# Altium schematic sheet [03] - 28PINS SCHEMATIC.SchDoc("[03] - 28PINS SCHEMATIC") (continued)

## Library data of the parts
C1 C2 = EEE-1AA101AP: Cap Aluminum 100uF 10V 20% (6.3 X 5.4mm) SMD 70mA 2000h 85°C T/R; Manufacturer: Panasonic; Dissipation Factor: 0.26%; Leakage Current: 3uA; Life (Hours): 2000Hour; Capacitance: 100uF; Case/Package: Radial; Category: Aluminum Electrolytic Capacitors; Component Kind: Standard; ComponentLink1Description: https://datasheet.ciiva.com/2985/aba0000ce115-2985038.pdf?src-supplier=Avnet; ComponentLink1URL: https://datasheet.ciiva.com/2985/aba0000ce115-2985038.pdf?src-supplier=Avnet; ComponentLink2Description: https://datasheet.ciiva.com/14604/70255709-14604540.pdf?src-supplier=Allied+Electronics; ComponentLink2URL: https://datasheet.ciiva.com/14604/70255709-14604540.pdf?src-supplier=Allied+Electronics; ComponentLink3Description: https://datasheet.ciiva.com/2985/aba0000ce115-2985039.pdf?src-supplier=Avnet; ComponentLink3URL: https://datasheet.ciiva.com/2985/aba0000ce115-2985039.pdf?src-supplier=Avnet; Construction: Cylindrical; Diameter: 6.3mm; Features: General Purpose; Height: 7.8mm; Height - Seated (Max): 0.213inch; Length: 5.5mm; Library Name: 28Pins_Project_V1I1.SchLib; Manufacturer Part Number: EEE-1AA101AP; Max Operating Temperature: 85°C; Min Operating Temperature: -40°C; Mount: Surface Mount,PCB; Package Quantity: 1000; Packaging: Tape and Reel; Pricing 1: 1=0.52000, 10=0.36000, 50=0.25200, 100=0.21600, 500=0.16200 (USD); Radiation Hardening: No; Ripple Current: 70mA; RoHS: Yes; RoHS Compliant: Yes; Series: VS; Stock 1: 1960; Supplier 1: Digi-Key; Supplier Part Number 1: PCE4565CT-ND; Termination: SMD/SMT; Tolerance: 20%; Voltage Rating: 10V; Voltage Rating (DC): 10V
C3 C12 C13 C19 C21 C22 C34 = C2012X5R1A106K085AB: C Series 0805 10 uF 10 V ±10 % Tolerance X5R SMT Multilayer Ceramic Capacitor; Manufacturer: TDK; Capacitance: 10uF; Tolerance: 10%; Voltage Rating: 10V; Case Code (Imperial): 0805; Case Code (Metric): 2012; Case/Package: 0805; Category: Ceramic Capacitors; Component Kind: Standard; ComponentLink1Description: https://datasheet.ciiva.com/26834/getdatasheetpartid-731846-26834407.pdf?src-supplier=Verical; ComponentLink1URL: https://datasheet.ciiva.com/26834/getdatasheetpartid-731846-26834407.pdf?src-supplier=Verical; ComponentLink2Description: https://datasheet.ciiva.com/7615/tdkmlcccaprange-7615065.pdf?src-supplier=Digi-Key; ComponentLink2URL: https://datasheet.ciiva.com/7615/tdkmlcccaprange-7615065.pdf?src-supplier=Digi-Key; ComponentLink3Description: https://datasheet.ciiva.com/7615/tdkmlcccaprange-7615066.pdf?src-supplier=Digi-Key; ComponentLink3URL: https://datasheet.ciiva.com/7615/tdkmlcccaprange-7615066.pdf?src-supplier=Digi-Key; ComponentLink4Description: https://datasheet.ciiva.com/7615/tdkmlcccaprange-7615067.pdf?src-supplier=Digi-Key; ComponentLink4URL: https://datasheet.ciiva.com/7615/tdkmlcccaprange-7615067.pdf?src-supplier=Digi-Key; Construction: Flat; Depth: 1.25mm; Dielectric: X5R; Dielectric Material: Ceramic; Height: 0.85mm; Lead Free: Lead Free; Length: 2mm; Library Name: 28Pins_Project_V1I1.SchLib; Manufacturer Part Number: C2012X5R1A106K085AB; Max Operating Temperature: 85°C; Min Operating Temperature: -55°C; Mount: Surface Mount; Package Quantity: 1; Packaging: Tape and Reel; Pricing 1: 1=0.26000, 10=0.17700, 50=0.11800, 100=0.10030, 500=0.07078, 1000=0.06193 (USD); Radiation Hardening: No; RoHS: Yes; RoHS Compliant: Yes; Series: C; Stock 1: 426139; Supplier 1: Digi-Key; Supplier Part Number 1: 445-7658-1-ND; Thickness: 0.037inch; Voltage Rating (DC): 10V; Width: 0.049inch
C4-C6 C8 C11 C14-C17 C20 C23 C24 C26 C28-C33 = C2012X7R1H104K085AA: C Series 0805 0.1 uF 50 V ±10 % Tolerance X7R SMT Multilayer Ceramic Capacitor; Manufacturer: TDK; Capacitance: 100nF; Tolerance: 10%; Voltage: 50V; Case Code (Imperial): 0805; Case Code (Metric): 2012; Case/Package: 0805; Category: Ceramic Capacitors; Component Kind: Standard; ComponentLink1Description: https://datasheet.ciiva.com/11788/0900766b80c1bb00-11788128.pdf?src-supplier=Rs; ComponentLink1URL: https://datasheet.ciiva.com/11788/0900766b80c1bb00-11788128.pdf?src-supplier=Rs; ComponentLink2Description: https://datasheet.ciiva.com/6250/tdkmlcccaprange-6250821.pdf?src-supplier=Digi-Key; ComponentLink2URL: https://datasheet.ciiva.com/6250/tdkmlcccaprange-6250821.pdf?src-supplier=Digi-Key; ComponentLink3Description: https://datasheet.ciiva.com/6250/tdkmlcccaprange-6250824.pdf?src-supplier=Digi-Key; ComponentLink3URL: https://datasheet.ciiva.com/6250/tdkmlcccaprange-6250824.pdf?src-supplier=Digi-Key; ComponentLink4Description: https://datasheet.ciiva.com/24191/e412-c-24191447.pdf?src-supplier=Future+Electronics; ComponentLink4URL: https://datasheet.ciiva.com/24191/e412-c-24191447.pdf?src-supplier=Future+Electronics; ComponentLink5Description: https://datasheet.ciiva.com/23803/1796654-23803035.pdf?src-supplier=Newark; ComponentLink5URL: https://datasheet.ciiva.com/23803/1796654-23803035.pdf?src-supplier=Newark; ComponentLink6Description: https://datasheet.ciiva.com/28602/1571323-28602204.pdf?src-supplier=Element14; ComponentLink6URL: https://datasheet.ciiva.com/28602/1571323-28602204.pdf?src-supplier=Element14; Construction: Flat; Depth: 1.25mm; Dielectric: X7R; Dielectric Material: Ceramic; Height: 0.85mm; Lead Free: Lead Free; Length: 2mm; Library Name: 28Pins_Project_V1I1.SchLib; Manufacturer Part Number: C2012X7R1H104K085AA; Max Operating Temperature: 125°C; Min Operating Temperature: -55°C; Mount: Surface Mount; Number of Pins: 2; Package Quantity: 1; Packaging: Tape and Reel; Pricing 1: 1=0.13000, 10=0.09500, 50=0.05400, 100=0.04480, 500=0.03142, 1000=0.02601 (USD); Radiation Hardening: No; Reach SVHC Compliant: Yes; Resistor Type: Ceramic; RoHS: Yes; RoHS Compliant: Yes; Series: C; Stock 1: 1513361; Supplier 1: Digi-Key; Supplier Part Number 1: 445-7534-1-ND; Termination: SMD/SMT; Thickness: 0.037inch; Voltage Rating: 50V; Voltage Rating (DC): 50V; Weight: 0.000194oz; Width: 0.049inch
C7 = C2012X5R1E105K125AA: CAP CER 1UF 25V X5R 0805; Manufacturer: TDK; Dielectric Material: Ceramic; Tolerance: 10%; Case Code (Imperial): 0805; Case Code (Metric): 2012; Case/Package: 0805; Category: Ceramic Capacitors; Component Kind: Standard; ComponentLink1Description: https://datasheet.ciiva.com/28602/1744655-28602171.pdf?src-supplier=Element14; ComponentLink1URL: https://datasheet.ciiva.com/28602/1744655-28602171.pdf?src-supplier=Element14; ComponentLink2Description: https://datasheet.ciiva.com/15192/getdatasheetpartid-726707-15192140.pdf?src-supplier=Verical; ComponentLink2URL: https://datasheet.ciiva.com/15192/getdatasheetpartid-726707-15192140.pdf?src-supplier=Verical; ComponentLink3Description: https://datasheet.ciiva.com/16449/e412-c-16449595.pdf?src-supplier=Future+Electronics; ComponentLink3URL: https://datasheet.ciiva.com/16449/e412-c-16449595.pdf?src-supplier=Future+Electronics; Construction: Flat; Depth: 1.25mm; Dielectric: X5R; Height: 1.25mm; Lead Free: Lead Free; Length: 2mm; Library Name: 28Pins_Project_V1I1.SchLib; Manufacturer Part Number: C2012X5R1E105K125AA; Max Operating Temperature: 85°C; Min Operating Temperature: -55°C; Mount: Surface Mount; Number of Pins: 2; Package Quantity: 2000; Packaging: Tape and Reel; Pricing 1: 1=0.21000, 10=0.14500, 50=0.08720, 100=0.07370, 500=0.05042, 1000=0.04460 (USD); Radiation Hardening: No; Reach SVHC Compliant: Yes; Resistor Type: Ceramic; RoHS: Yes; RoHS Compliant: Yes; Series: C; Stock 1: 32867; Supplier 1: Digi-Key; Supplier Part Number 1: 445-1419-1-ND; Termination: SMD/SMT; Thickness: 0.057inch; Voltage Rating: 25V; Voltage Rating (DC): 25V; Weight: 0.000194oz; Width: 0.049inch
C9 C10 C25 C27 = C0805C180J5GACAUTO: .050 X .050 C.L. FEMALE IDC ASSE; Manufacturer: KEMET; Category: Ceramic Capacitors; Component Kind: Standard; Library Name: 28Pins_Project_V1I1.SchLib; Manufacturer Part Number: C0805C180J5GACAUTO; Pricing 1: 1=0.18000, 10=0.12600, 50=0.07160, 100=0.05930, 500=0.04158, 1000=0.03443 (USD); RoHS: Yes; RoHS Compliant: Yes; Stock 1: 1163; Supplier 1: Digi-Key; Supplier Part Number 1: 399-C0805C180J5GACAUTOCT-ND
D1 = LG L29K-F2J1-24-Z: GREEN LED; Component Kind: Standard; Library Name: 28Pins_Project_V1I1.SchLib
D2-D4 = LO L29K-H2K1-24-Z: ORANGE LED MISSING LINK TO DIGIKEY; Component Kind: Standard; Library Name: 28Pins_Project_V1I1.SchLib
D5 D6 = BAT54HT1G: VR=30V IF=200mA 10pF P=200mW; Manufacturer: ON Semiconductor / Fairchild; Average Rectified Current: 200mA; Element Configuration: Single; Forward Voltage: 800mV; Max Forward Surge Current (Ifsm): 600mA; Max Repetitive Reverse Voltage (Vrrm): 30V; Max Reverse Current: 2uA; Polarity: Standard; Power Dissipation: 200mW; Recovery Time: 5ns; Capacitance: 10pF; Category: Schottky Diodes; Component Kind: Standard; ComponentLink1Description: https://datasheet.ciiva.com/1180/bat54ht1-d-1180251.pdf?src-supplier=Element14; ComponentLink1URL: https://datasheet.ciiva.com/1180/bat54ht1-d-1180251.pdf?src-supplier=Element14; ComponentLink2Description: https://datasheet.ciiva.com/1180/bat54ht1-d-1180252.pdf?src-supplier=Element14; ComponentLink2URL: https://datasheet.ciiva.com/1180/bat54ht1-d-1180252.pdf?src-supplier=Element14; ComponentLink3Description: https://datasheet.ciiva.com/46429/1727620-46429206.pdf?src-supplier=Element14; ComponentLink3URL: https://datasheet.ciiva.com/46429/1727620-46429206.pdf?src-supplier=Element14; ComponentLink4Description: https://datasheet.ciiva.com/48686/001262925-da-01-en-diode-schottky-30v-bat54ht1g-sod-323-fsc-48686266.pdf?src-supplier=Conrad; ComponentLink4URL: https://datasheet.ciiva.com/48686/001262925-da-01-en-diode-schottky-30v-bat54ht1g-sod-323-fsc-48686266.pdf?src-supplier=Conrad; Contact Plating: Tin,Matte; Current Rating: 200mA; Forward Current: 200mA; Height: 1mm; Lead Free: Lead Free; Length: 1.8mm; Library Name: 28Pins_Project_V1I1.SchLib; Manufacturer Part Number: BAT54HT1G; Max Operating Temperature: 150°C; Max Reverse Leakage Current: 2uA; Max Reverse Voltage (DC): 30V; Max Surge Current: 600mA; Min Operating Temperature: -55°C; Mount: Surface Mount; Number of Pins: 2; Output Current: 200mA; Package Quantity: 1; Packaging: Tape and Reel; Peak Non-Repetitive Surge Current: 600mA; Pricing 1: 1=0.24000, 10=0.19900, 100=0.10570, 500=0.06956, 1000=0.04730 (USD); Radiation Hardening: No; Reach SVHC Compliant: Yes; Reverse Recovery Time: 5ns; Reverse Recovery Time-Max: 5ns; Reverse Voltage: 30V; RoHS: Yes; RoHS Compliant: Yes; Series: -; Stock 1: 25035; Supplier 1: Digi-Key; Supplier Part Number 1: BAT54HT1GOSCT-ND; Voltage Rating (DC): 30V; Width: 1.35mm
FB1-FB3 = MPZ2012S601AT000: 0805 600Ω ±25% DCR=0.1Ω 2A; Manufacturer: TDK; DC Resistance (DCR): 100mR; Impedance: 600R; Number of Lines: 1; Case Code (Imperial): 0805; Case Code (Metric): 2012; Case/Package: 0805; Category: Ferrite Beads and Chips; Component Kind: Standard; ComponentLink1Description: https://datasheet.ciiva.com/46634/beads-commercial-power-mpz-en-46634335.pdf?src-supplier=Digi-Key; ComponentLink1URL: https://datasheet.ciiva.com/46634/beads-commercial-power-mpz-en-46634335.pdf?src-supplier=Digi-Key; ComponentLink2Description: https://datasheet.ciiva.com/46634/beads-commercial-power-mpz-en-46634377.pdf?src-supplier=Digi-Key; ComponentLink2URL: https://datasheet.ciiva.com/46634/beads-commercial-power-mpz-en-46634377.pdf?src-supplier=Digi-Key; ComponentLink3Description: https://datasheet.ciiva.com/46634/beads-commercial-power-mpz-en-46634606.pdf?src-supplier=Digi-Key; ComponentLink3URL: https://datasheet.ciiva.com/46634/beads-commercial-power-mpz-en-46634606.pdf?src-supplier=Digi-Key; Current Rating: 2A; Height: 0.041inch; Lead Free: Lead Free; Length: 0.079inch; Library Name: 28Pins_Project_V1I1.SchLib; Manufacturer Part Number: MPZ2012S601AT000; Max Current Rating: 2A; Max DC Current: 2A; Max Operating Temperature: 125°C; Min Operating Temperature: -55°C; Mount: Surface Mount; Package Quantity: 1; Packaging: Tape and Reel; Pricing 1: 1=0.11000, 10=0.09200, 25=0.08320, 50=0.06100, 100=0.05270, 250=0.04160, 500=0.03512, 1000=0.02957 (USD); Reach SVHC Compliant: Yes; RoHS: Yes; RoHS Compliant: Yes; Series: MPZ; Stock 1: 43; Supplier 1: Digi-Key; Supplier Part Number 1: 445-MPZ2012S601AT000CT-ND; Width: 0.049inch
FID1-FID4 = FID_1MMC: Fiducial 40 mil, Diameter, 120 mil mask; Library Name: 28Pins_Project_V1I1.SchLib
J1 J3 = M20-7820842: 2.54mm (0.1") Pitch SIL Vertical PC Tail Socket Assembly, selective gold + tin, 8 contacts; Manufacturer: Harwin; Connector Type: Receptacle; Contact Resistance: 20mR; Gender: Female; Max Current Rating: 3A; Number of Contacts: 8; Case/Package: SIL; Category: Headers and Wire Housings; Color: Black; Component Kind: Standard; ComponentLink1Description: https://datasheet.ciiva.com/9480/m20-782-9480074.pdf?src-supplier=Avnet; ComponentLink1URL: https://datasheet.ciiva.com/9480/m20-782-9480074.pdf?src-supplier=Avnet; Contact Gender: Female; Contact Material: Bronze; Contact Plating: Tin,Gold; Contact Type: Female Socket; Depth: 2.5mm; Housing Color: Black; Housing Material: Polyamide; Length: 20.72mm; Library Name: 28Pins_Project_V1I1.SchLib; Manufacturer Part Number: M20-7820842; Max Operating Temperature: 105°C; Min Operating Temperature: -40°C; Mount: Through Hole; Number of Positions: 8; Number of Rows: 1; Orientation: Straight; Package Quantity: 29; Packaging: Rail/Tube; Pricing 1: 1=1.44000, 10=1.21500, 100=1.09100, 500=0.89264, 1000=0.79346, 5000=0.69427, 10000=0.65956 (USD); Radiation Hardening: No; RoHS: Yes; RoHS Compliant: Yes; Series: M20; Supplier 1: Digi-Key; Supplier Part Number 1: 952-1823-ND; Termination: Solder; Weight: 0.025397oz
  J3: Pitch: 2.54mm
J2 = M20-7820646: 2.54mm (0.1") Pitch SIL Vertical PC Tail Socket Assembly, tin, 6 contacts; Manufacturer: Harwin; Connector Type: Receptacle; Contact Resistance: 20mR; Gender: Female; Max Current Rating: 3A; Number of Contacts: 6; Case/Package: SIL; Category: Headers and Wire Housings; Color: Black; Component Kind: Standard; ComponentLink1Description: https://cdn.harwin.com/pdfs/M20-782.pdf?src-supplier=Farnell; ComponentLink1URL: https://cdn.harwin.com/pdfs/M20-782.pdf?src-supplier=Farnell; ComponentLink2Description: http://www.harwin.com/include/downloads/pdfs/2010PAGE120.PDF?src-supplier=Avnet; ComponentLink2URL: http://www.harwin.com/include/downloads/pdfs/2010PAGE120.PDF?src-supplier=Avnet; ComponentLink3Description: https://cdn.harwin.com/pdfs/M20-782.pdf?src-supplier=Digi-Key; ComponentLink3URL: https://cdn.harwin.com/pdfs/M20-782.pdf?src-supplier=Digi-Key; ComponentLink4Description: https://cdn.harwin.com/pdfs/Harwin_PC_Connectors_M20.pdf?src-supplier=Mouser; ComponentLink4URL: https://cdn.harwin.com/pdfs/Harwin_PC_Connectors_M20.pdf?src-supplier=Mouser; ComponentLink5Description: https://cdn.harwin.com/pdfs/M20-782.pdf?src-supplier=Newark; ComponentLink5URL: https://cdn.harwin.com/pdfs/M20-782.pdf?src-supplier=Newark; ComponentLink6Description: https://cdn.harwin.com/pdfs/Harwin_PC_Connectors_M20.pdf?src-supplier=Rs; ComponentLink6URL: https://cdn.harwin.com/pdfs/Harwin_PC_Connectors_M20.pdf?src-supplier=Rs; ComponentLink7Description: https://cdn.harwin.com/pdfs/Harwin_PC_Connectors_M20.pdf?src-supplier=TTI; ComponentLink7URL: https://cdn.harwin.com/pdfs/Harwin_PC_Connectors_M20.pdf?src-supplier=TTI; Contact Gender: Female; Contact Material: Bronze; Contact Plating: Tin; Contact Type: Female Socket; Depth: 2.5mm; Housing Color: Black; Housing Material: Nylon; Length: 15.64mm; Library Name: 28Pins_Project_V1I1.SchLib; Manufacturer Part Number: M20-7820646; Max Operating Temperature: 105°C; Min Operating Temperature: -40°C; Mount: Through Hole; Number of Positions: 6; Number of Rows: 1; Orientation: Straight; Package Quantity: 38; Packaging: Rail/Tube; Pitch: 2.54mm; Pricing 1: 1=1.24000, 10=1.05200, 100=0.94440, 500=0.77264, 1000=0.68680, 5000=0.60095, 10000=0.57090 (USD); Radiation Hardening: No; RoHS: Yes; RoHS Compliant: Yes; Series: M20; Supplier 1: Digi-Key; Supplier Part Number 1: 952-1809-ND; Termination: Solder
J4 = M20-7821046: 2.54mm (0.1") Pitch SIL Vertical PC Tail Socket Assembly, tin, 10 contacts; Manufacturer: Harwin; Connector Type: Receptacle; Contact Resistance: 20mR; Current Rating: 3A; Hole Diameter: 0.8mm; Insulation Resistance: 1MR; Mated Stacking Heights: 12.63mm; Mating Cycles: 50; Number of Contacts: 10; Voltage Rating: 1kV; Case/Package: SIL; Category: Headers and Wire Housings; Color: Black; Component Kind: Standard; ComponentLink1Description: https://datasheet.ciiva.com/11753/0900766b80dc1d2b-11753869.pdf?src-supplier=Rs; ComponentLink1URL: https://datasheet.ciiva.com/11753/0900766b80dc1d2b-11753869.pdf?src-supplier=Rs; ComponentLink2Description: https://datasheet.ciiva.com/26899/getdatasheetpartid-559226-26899379.pdf?src-supplier=Verical; ComponentLink2URL: https://datasheet.ciiva.com/26899/getdatasheetpartid-559226-26899379.pdf?src-supplier=Verical; ComponentLink3Description: https://datasheet.ciiva.com/10151/m20-782-10151366.pdf?src-supplier=Avnet; ComponentLink3URL: https://datasheet.ciiva.com/10151/m20-782-10151366.pdf?src-supplier=Avnet; ComponentLink4Description: https://datasheet.ciiva.com/6785/m20-782-6785605.pdf?src-supplier=Digi-Key; ComponentLink4URL: https://datasheet.ciiva.com/6785/m20-782-6785605.pdf?src-supplier=Digi-Key; Contact Finish - Mating: TIN; Contact Gender: Female; Contact Material: Bronze; Contact Plating: Tin; Contact Shape: Square; Contact Type: Female Socket; Depth: 2.5mm; Fastening Type: Pull; Flammability Rating: UL94 V-0; Gender: Receptacle; Housing Color: Black; Housing Material: Nylon; Insulation Color: Black; Insulation Material: Nylon; Lead Pitch: 2.54mm; Length: 25.8mm; Library Name: 28Pins_Project_V1I1.SchLib; Manufacturer Part Number: M20-7821046; Max Current Rating: 3A; Max Operating Temperature: 105°C; Min Operating Temperature: -40°C; Mount: Through Hole; Number of Positions: 10; Number of Rows: 1; Orientation: Straight; Package Quantity: 23; Packaging: Rail/Tube; Pitch: 2.54mm; Pricing 1: 1=1.46000, 10=1.27000, 100=1.13470, 500=0.94562, 1000=0.81052, 5000=0.71867, 10000=0.70246 (USD); Radiation Hardening: No; Reach SVHC Compliant: Yes; RoHS: Yes; RoHS Compliant: Yes; Series: M20; Stock 1: 6988; Supplier 1: Digi-Key; Supplier Part Number 1: 952-1847-ND; Termination: Solder
J5 J6 = 67997-106HLF: Conn, Header, 6POS, 2ROW, 2.54MM, Th;; Manufacturer: Amphenol Communications Solutions; Category: Connectors; Component Kind: Standard; Library Name: 28Pins_Project_V1I1.SchLib; Manufacturer Part Number: 67997-106HLF; Pricing 1: 1=0.63000, 10=0.54700, 100=0.45110, 500=0.39228, 1000=0.32363, 5000=0.28441, 10000=0.26479 (USD); RoHS: No; Stock 1: 1817; Supplier 1: Digi-Key; Supplier Part Number 1: 609-3393-ND
J7 = 10118193-0001LF: FCI Right Angle Surface Mount Mount Female USB Connector; 100 V ac; 1.8A; Manufacturer: Amphenol ICC / FCI; Category: USB Connectors; Component Kind: Standard; ComponentLink1Description: http://uk.rs-online.com/webdocs/1450/0900766b814507f1.pdf?src-supplier=Rs; ComponentLink1URL: http://uk.rs-online.com/webdocs/1450/0900766b814507f1.pdf?src-supplier=Rs; ComponentLink2Description: https://cdn.amphenol-icc.com/media/wysiwyg/files/documentation/datasheet/inputoutput/io_usb_micro.pdf?src-supplier=Rs; ComponentLink2URL: https://cdn.amphenol-icc.com/media/wysiwyg/files/documentation/datasheet/inputoutput/io_usb_micro.pdf?src-supplier=Rs; ComponentLink3Description: https://cdn.amphenol-icc.com/media/wysiwyg/files/documentation/datasheet/inputoutput/io_usb_micro.pdf?src-supplier=Onlinecomponents.com; ComponentLink3URL: https://cdn.amphenol-icc.com/media/wysiwyg/files/documentation/datasheet/inputoutput/io_usb_micro.pdf?src-supplier=Onlinecomponents.com; ComponentLink4Description: https://cdn.amphenol-icc.com/media/wysiwyg/files/documentation/datasheet/inputoutput/io_usb_micro.pdf?src-supplier=Arrow+Electronics; ComponentLink4URL: https://cdn.amphenol-icc.com/media/wysiwyg/files/documentation/datasheet/inputoutput/io_usb_micro.pdf?src-supplier=Arrow+Electronics; ComponentLink5Description: https://cdn.amphenol-icc.com/media/wysiwyg/files/documentation/datasheet/inputoutput/io_usb_micro.pdf?src-supplier=Allied+Electronics; ComponentLink5URL: https://cdn.amphenol-icc.com/media/wysiwyg/files/documentation/datasheet/inputoutput/io_usb_micro.pdf?src-supplier=Allied+Electronics; ComponentLink6Description: https://cdn.amphenol-icc.com/media/wysiwyg/files/documentation/datasheet/inputoutput/io_usb_micro.pdf?src-supplier=Verical; ComponentLink6URL: https://cdn.amphenol-icc.com/media/wysiwyg/files/documentation/datasheet/inputoutput/io_usb_micro.pdf?src-supplier=Verical; ComponentLink7Description: https://cdn.amphenol-icc.com/media/wysiwyg/files/documentation/datasheet/inputoutput/io_usb_micro.pdf?src-supplier=Avnet; ComponentLink7URL: https://cdn.amphenol-icc.com/media/wysiwyg/files/documentation/datasheet/inputoutput/io_usb_micro.pdf?src-supplier=Avnet; ComponentLink8Description: https://cdn.amphenol-icc.com/media/wysiwyg/files/documentation/datasheet/inputoutput/io_usb_micro.pdf?src-supplier=Mouser; ComponentLink8URL: https://cdn.amphenol-icc.com/media/wysiwyg/files/documentation/datasheet/inputoutput/io_usb_micro.pdf?src-supplier=Mouser; ComponentLink9Description: http://www.amphenol-icc.com/media/wysiwyg/files/drawing/10118193.pdf?src-supplier=Digi-Key; ComponentLink9URL: http://www.amphenol-icc.com/media/wysiwyg/files/drawing/10118193.pdf?src-supplier=Digi-Key; ComponentLink10Description: https://cdn.amphenol-icc.com/media/wysiwyg/files/documentation/datasheet/inputoutput/io_usb_micro.pdf?src-supplier=Digi-Key; ComponentLink10URL: https://cdn.amphenol-icc.com/media/wysiwyg/files/documentation/datasheet/inputoutput/io_usb_micro.pdf?src-supplier=Digi-Key; ComponentLink11Description: https://cdn.amphenol-icc.com/media/wysiwyg/files/documentation/datasheet/inputoutput/io_usb_micro.pdf?src-supplier=Heilind+Electronics; ComponentLink11URL: https://cdn.amphenol-icc.com/media/wysiwyg/files/documentation/datasheet/inputoutput/io_usb_micro.pdf?src-supplier=Heilind+Electronics; ComponentLink12Description: https://cdn.amphenol-icc.com/media/wysiwyg/files/documentation/datasheet/inputoutput/io_usb_micro.pdf?src-supplier=TTI; ComponentLink12URL: https://cdn.amphenol-icc.com/media/wysiwyg/files/documentation/datasheet/inputoutput/io_usb_micro.pdf?src-supplier=TTI; ComponentLink13Description: https://cdn.amphenol-icc.com/media/wysiwyg/files/documentation/datasheet/inputoutput/io_usb_micro.pdf?src-supplier=TME; ComponentLink13URL: https://cdn.amphenol-icc.com/media/wysiwyg/files/documentation/datasheet/inputoutput/io_usb_micro.pdf?src-supplier=TME; Library Name: 28Pins_Project_V1I1.SchLib; Manufacturer Part Number: 10118193-0001LF; Pricing 1: 1=0.460000, 10=0.404000, 25=0.369600, 50=0.347800, 100=0.333400, 250=0.304360, 500=0.289880 (USD); RoHS: No; Stock 1: 328321; Supplier 1: Digi-Key; Supplier Part Number 1: 609-4616-1-ND
J8 J9 = PAD_WITH_HOLE_4MMx1.5MM: Library Name: 28Pins_Project_V1I1.SchLib
JP1 JP4 = 68000-103HLF: 68000 Series Single Row 3 Position 2.54 mm Pitch Straight Bergstik II Header; Manufacturer: Amphenol Communications Solutions; Category: Connectors; Component Kind: Standard; Library Name: 28Pins_Project_V1I1.SchLib; Manufacturer Part Number: 68000-103HLF; Pricing 1: 1=0.37000, 10=0.31700, 100=0.24620, 500=0.21104, 1000=0.17587, 5000=0.15074, 10000=0.14069 (USD); RoHS: No; Stock 1: 74816; Supplier 1: Digi-Key; Supplier Part Number 1: 609-3461-ND
JP2 = 67997-204HLF: Conn Header Vert 4POS 2.54MM; Manufacturer: Amphenol Communications Solutions; Component Kind: Standard; Library Name: 28Pins_Project_V1I1.SchLib; Manufacturer Part Number: 67997-204HLF; Pricing 1: 1=0.42000, 10=0.36000, 100=0.28010, 500=0.24010, 1000=0.20008, 5000=0.17150, 10000=0.16007 (USD); RoHS: No; Stock 1: 6237; Supplier 1: Digi-Key; Supplier Part Number 1: 609-3233-ND
JP3 = 68001-204HLF: 4 POS 2.54mm Solder ST Thru-Hole Unshrouded Header; Manufacturer: Amphenol Communications Solutions; Category: Connectors; Component Kind: Standard; Library Name: 28Pins_Project_V1I1.SchLib; Manufacturer Part Number: 68001-204HLF; Pricing 1: 1=0.48000, 10=0.40700, 100=0.31620, 500=0.27106, 1000=0.22589, 5000=0.19362, 10000=0.18071 (USD); RoHS: No; Stock 1: 7165; Supplier 1: Digi-Key; Supplier Part Number 1: 609-3402-ND
L1 = L1210R2R2MDWIT: Inductor RF Chip Wirewound 2.2uH 20% 100KHz 1.13A 0.104Ohm DCR 1210 T/R; Manufacturer: KEMET; Core Material: Ferrite; DC Resistance (DCR): 80mR; Self Resonant Frequency: 190MHz; Shielding: Unshielded; Case Code (Imperial): 1210; Case Code (Metric): 3225; Case/Package: 1210; Category: Fixed Inductors; Component Kind: Standard; ComponentLink1Description: https://datasheet.ciiva.com/8512/f3115-8512543.pdf?src-supplier=Avnet; ComponentLink1URL: https://datasheet.ciiva.com/8512/f3115-8512543.pdf?src-supplier=Avnet; ComponentLink2Description: https://datasheet.ciiva.com/6691/f3115-6691487.pdf?src-supplier=Digi-Key; ComponentLink2URL: https://datasheet.ciiva.com/6691/f3115-6691487.pdf?src-supplier=Digi-Key; ComponentLink3Description: https://datasheet.ciiva.com/4957/f3115-4957838.pdf?src-supplier=Mouser; ComponentLink3URL: https://datasheet.ciiva.com/4957/f3115-4957838.pdf?src-supplier=Mouser; ComponentLink4Description: https://datasheet.ciiva.com/22685/27262-22685038.pdf?src-supplier=Element14; ComponentLink4URL: https://datasheet.ciiva.com/22685/27262-22685038.pdf?src-supplier=Element14; DC Current: 1.13A; Depth: 2.7mm; Height: 2.5mm; Height - Seated (Max): 0.106inch; Inductance: 2.2uH; Inductance Tolerance: 20%; Length: 3.2mm; Library Name: 28Pins_Project_V1I1.SchLib; Manufacturer Part Number: L1210R2R2MDWIT; Max DC Current: 2A; Max Operating Temperature: 105°C; Military Standard: Not; Min Operating Temperature: -40°C; Mount: Surface Mount; Package Quantity: 1000; Packaging: Tape and Reel; Pricing 1: 1=0.48000, 10=0.42100, 25=0.39080, 50=0.33060, 100=0.30050, 250=0.28244, 500=0.25240 (USD); Radiation Hardening: No; Reach SVHC Compliant: Yes; Resistor Type: Wirewound,Ceramic; RoHS: Yes; RoHS Compliant: Yes; Series: L-DWI; Stock 1: 21680; Supplier 1: Digi-Key; Supplier Part Number 1: 399-9598-1-ND; Termination: SMD/SMT; Test Frequency: 100kHz; Tolerance: 20%; Width: 2.5mm
LINK1-LINK3 = QPC02SXGN-RC: CONN JUMPER SHORTING .100'' GOLD; Manufacturer: Sullins; Number of Positions: 2; Pitch: 0.1inch; Case/Package: 100; Category: Connectors; ComponentLink1Description: https://datasheet.ciiva.com/767/284-sxgn-11510-767869.pdf?src-supplier=Digi-Key; ComponentLink1URL: https://datasheet.ciiva.com/767/284-sxgn-11510-767869.pdf?src-supplier=Digi-Key; Contact Plating: Gold; Height: 0.235inch; Lead Free: Lead Free; Library Name: 28Pins_Project_V1I1.SchLib; Manufacturer Part Number: QPC02SXGN-RC; Package Quantity: 2000; Packaging: Bulk; Pricing 1: 1=0.10000, 10=0.05000, 25=0.04080, 50=0.03780, 100=0.03650, 250=0.03152, 500=0.03024, 1000=0.02646, 2500=0.02394 (USD); RoHS: Yes; RoHS Compliant: Yes; Stock 1: 519222; Supplier 1: Digi-Key; Supplier Part Number 1: S9337-ND
MH1-MH4 = MH_7.4MMNC_3.2MMD: Hole 7.4mm Diameter (Non Copper) 3.2mm Drill NPTH; Library Name: 28Pins_Project_V1I1.SchLib
R1 = RC0805FR-07100KL: Res Thick Film 0805 100K Ohm 1% 1/8W ±100ppm/°C Molded SMD Paper T/R; Manufacturer: Yageo; Max Power Dissipation: 125mW; Resistance: 100kR; Voltage Rating: 150V; Case Code (Imperial): 0805; Case Code (Metric): 2012; Case/Package: 0805; Category: Chip SMD Resistors; Component Kind: Standard; ComponentLink1Description: https://datasheet.ciiva.com/11808/0900766b8106beab-11808858.pdf?src-supplier=Rs; ComponentLink1URL: https://datasheet.ciiva.com/11808/0900766b8106beab-11808858.pdf?src-supplier=Rs; ComponentLink2Description: https://datasheet.ciiva.com/7941/1686128-7941677.pdf?src-supplier=Element14; ComponentLink2URL: https://datasheet.ciiva.com/7941/1686128-7941677.pdf?src-supplier=Element14; ComponentLink3Description: https://datasheet.ciiva.com/7660/38627-7660796.pdf?src-supplier=Element14; ComponentLink3URL: https://datasheet.ciiva.com/7660/38627-7660796.pdf?src-supplier=Element14; ComponentLink4Description: https://datasheet.ciiva.com/22747/444164-22747534.pdf?src-supplier=Element14; ComponentLink4URL: https://datasheet.ciiva.com/22747/444164-22747534.pdf?src-supplier=Element14; ComponentLink5Description: https://datasheet.ciiva.com/2457/pyu-r-int-thick-7-2457018.pdf?src-supplier=Digi-Key; ComponentLink5URL: https://datasheet.ciiva.com/2457/pyu-r-int-thick-7-2457018.pdf?src-supplier=Digi-Key; ComponentLink6Description: https://datasheet.ciiva.com/2457/pyu-r-int-thick-7-2457019.pdf?src-supplier=Digi-Key; ComponentLink6URL: https://datasheet.ciiva.com/2457/pyu-r-int-thick-7-2457019.pdf?src-supplier=Digi-Key; ComponentLink7Description: https://datasheet.ciiva.com/2457/pyu-r-int-thick-7-2457017.pdf?src-supplier=Digi-Key; ComponentLink7URL: https://datasheet.ciiva.com/2457/pyu-r-int-thick-7-2457017.pdf?src-supplier=Digi-Key; ComponentLink8Description: https://datasheet.ciiva.com/12667/rc0805-12667001.pdf?src-supplier=Mouser; ComponentLink8URL: https://datasheet.ciiva.com/12667/rc0805-12667001.pdf?src-supplier=Mouser; ComponentLink9Description: https://datasheet.ciiva.com/7941/1697719-7941676.pdf?src-supplier=Element14; ComponentLink9URL: https://datasheet.ciiva.com/7941/1697719-7941676.pdf?src-supplier=Element14; ComponentLink10Description: https://datasheet.ciiva.com/7941/1697719-7941678.pdf?src-supplier=Element14; ComponentLink10URL: https://datasheet.ciiva.com/7941/1697719-7941678.pdf?src-supplier=Element14; ComponentLink11Description: https://datasheet.ciiva.com/16747/rc0805-16747226.pdf?src-supplier=Future+Electronics; ComponentLink11URL: https://datasheet.ciiva.com/16747/rc0805-16747226.pdf?src-supplier=Future+Electronics; Construction: Rectangular; Depth: 1.25mm; Features: Moisture Resistant; Height: 0.024inch; Lead Free: Lead Free; Lead Pitch: 0.4mm; Length: 0.079inch; Library Name: 28Pins_Project_V1I1.SchLib; Manufacturer Part Number: RC0805FR-07100KL; Max Operating Temperature: 155°C; Military Standard: Not; Min Operating Temperature: -55°C; Number of Pins: 2; Number of Terminations: 2; Package Quantity: 1; Packaging: Tape and Reel; Power Rating: 125mW; Pricing 1: 1=0.10000, 10=0.03300, 100=0.01330, 1000=0.00600, 2500=0.00520 (USD); Resistance Tolerance: 1%; Resistor Type: Thick Film; RoHS: Yes; RoHS Compliant: Yes; Series: RC; Size Code: 0805; Stock 1: 5808430; Supplier 1: Digi-Key; Supplier Part Number 1: 311-100KCRCT-ND; Technology: THICK FILM; Temperature Coefficient: 100ppm/°C; Termination: SMD/SMT; Tolerance: 1%; Voltage Rating (DC): 150V; Width: 0.049inch
R2 R3 R15 R17 R22 = RC0402FR-07560RL: Res Thick Film 0402 560 Ohm 1% 0.063W(1/16W) ±100ppm/C Molded SMD Paper T/R; Manufacturer: Yageo; Max Power Dissipation: 63mW; Temperature Coefficient: 100ppm/°C; Voltage Rating: 50V; Case Code (Imperial): 0402; Case Code (Metric): 1005; Case/Package: 0402; Category: Chip SMD Resistors; Component Kind: Standard; ComponentLink1Description: https://datasheet.ciiva.com/2453/pyu-r-int-thick-7-2453458.pdf?src-supplier=Digi-Key; ComponentLink1URL: https://datasheet.ciiva.com/2453/pyu-r-int-thick-7-2453458.pdf?src-supplier=Digi-Key; ComponentLink2Description: https://datasheet.ciiva.com/2453/pyu-r-int-thick-7-2453459.pdf?src-supplier=Digi-Key; ComponentLink2URL: https://datasheet.ciiva.com/2453/pyu-r-int-thick-7-2453459.pdf?src-supplier=Digi-Key; ComponentLink3Description: https://datasheet.ciiva.com/2453/pyu-r-int-thick-7-2453457.pdf?src-supplier=Digi-Key; ComponentLink3URL: https://datasheet.ciiva.com/2453/pyu-r-int-thick-7-2453457.pdf?src-supplier=Digi-Key; ComponentLink4Description: https://datasheet.ciiva.com/12558/pyu-rc0402-51-rohs-l-6-r-8721-12558383.pdf?src-supplier=Mouser; ComponentLink4URL: https://datasheet.ciiva.com/12558/pyu-rc0402-51-rohs-l-6-r-8721-12558383.pdf?src-supplier=Mouser; ComponentLink5Description: https://datasheet.ciiva.com/7850/1018594-7850042.pdf?src-supplier=Element14; ComponentLink5URL: https://datasheet.ciiva.com/7850/1018594-7850042.pdf?src-supplier=Element14; ComponentLink6Description: https://datasheet.ciiva.com/7850/1018594-7850043.pdf?src-supplier=Element14; ComponentLink6URL: https://datasheet.ciiva.com/7850/1018594-7850043.pdf?src-supplier=Element14; Construction: Rectangular; Depth: 0.5mm; Features: Moisture Resistant; Height: 0.016inch; Lead Free: Lead Free; Length: 1mm; Library Name: 28Pins_Project_V1I1.SchLib; Manufacturer Part Number: RC0402FR-07560RL; Max Operating Temperature: 155°C; Military Standard: Not; Min Operating Temperature: -55°C; Number of Terminations: 2; Package Quantity: 1; Packaging: Tape and Reel; Power Rating: 63mW; Pricing 1: 1=0.10000, 10=0.01400, 100=0.00580, 1000=0.00262, 2500=0.00228, 5000=0.00188 (USD); Resistance: 560R; Resistance Tolerance: 1%; Resistor Type: Thick Film; RoHS: Yes; RoHS Compliant: Yes; Series: RC; Size Code: 0402; Stock 1: 1061633; Supplier 1: Digi-Key; Supplier Part Number 1: 311-560LRCT-ND; Termination: SMD/SMT; Tolerance: 1%; Width: 0.02inch
R4 R14 R18-R21 = AC0805FR-0710KL: Res Thick Film 0805 10K Ohm 1% 0.125W(1/8W) ±100ppm/C Pad SMD Automotive T/R; Manufacturer: Yageo; Max Power Dissipation: 125mW; Resistance: 10kR; Temperature Coefficient: 100ppm/°C; Voltage Rating: 150V; Case Code (Imperial): 0805; Case Code (Metric): 2012; Case/Package: 0805; Category: Chip SMD Resistors; Component Kind: Standard; ComponentLink1Description: https://datasheet.ciiva.com/5558/pyu-ac-51-rohs-l-2-5558347.pdf?src-supplier=Mouser; ComponentLink1URL: https://datasheet.ciiva.com/5558/pyu-ac-51-rohs-l-2-5558347.pdf?src-supplier=Mouser; Construction: Rectangular; Depth: 1.25mm; Features: Moisture Resistant,Automotive AEC-Q200,Anti-Sulfur; Height: 0.024inch; Lead Free: Lead Free; Length: 0.079inch; Library Name: 28Pins_Project_V1I1.SchLib; Manufacturer Part Number: AC0805FR-0710KL; Max Operating Temperature: 155°C; Military Standard: Not; Min Operating Temperature: -55°C; Number of Terminations: 2; Package Quantity: 1; Packaging: Tape and Reel; Power Rating: 125mW; Pricing 1: 1=0.10000, 10=0.04400, 100=0.01790, 1000=0.00806, 2500=0.00699 (USD); Resistor Type: Thick Film; RoHS: Yes; RoHS Compliant: Yes; Series: AC; Size Code: 0805; Stock 1: 699888; Supplier 1: Digi-Key; Supplier Part Number 1: 311-10KLGCT-ND; Termination: SMD/SMT; Tolerance: 1%; Width: 0.049inch
R5-R7 R13 R23-R25 = RC0805FR-0722RL: Res Thick Film 0805 22 Ohm 1% 0.125W(1/8W) ±100ppm/C Pad SMD T/R; Manufacturer: Yageo; Max Power Dissipation: 125mW; Temperature Coefficient: 100ppm/°C; Voltage Rating: 150V; Case Code (Imperial): 0805; Case Code (Metric): 2012; Case/Package: 0805; Category: Chip SMD Resistors; Component Kind: Standard; ComponentLink1Description: https://datasheet.ciiva.com/11819/0900766b8106beab-11819837.pdf?src-supplier=Rs; ComponentLink1URL: https://datasheet.ciiva.com/11819/0900766b8106beab-11819837.pdf?src-supplier=Rs; ComponentLink2Description: https://datasheet.ciiva.com/46447/444164-46447574.pdf?src-supplier=Element14; ComponentLink2URL: https://datasheet.ciiva.com/46447/444164-46447574.pdf?src-supplier=Element14; ComponentLink3Description: https://datasheet.ciiva.com/2457/pyu-r-int-thick-7-2457045.pdf?src-supplier=Digi-Key; ComponentLink3URL: https://datasheet.ciiva.com/2457/pyu-r-int-thick-7-2457045.pdf?src-supplier=Digi-Key; ComponentLink4Description: https://datasheet.ciiva.com/2457/pyu-r-int-thick-7-2457046.pdf?src-supplier=Digi-Key; ComponentLink4URL: https://datasheet.ciiva.com/2457/pyu-r-int-thick-7-2457046.pdf?src-supplier=Digi-Key; ComponentLink5Description: https://datasheet.ciiva.com/2457/pyu-r-int-thick-7-2457044.pdf?src-supplier=Digi-Key; ComponentLink5URL: https://datasheet.ciiva.com/2457/pyu-r-int-thick-7-2457044.pdf?src-supplier=Digi-Key; ComponentLink6Description: https://datasheet.ciiva.com/12667/rc0805-12667371.pdf?src-supplier=Mouser; ComponentLink6URL: https://datasheet.ciiva.com/12667/rc0805-12667371.pdf?src-supplier=Mouser; Construction: Rectangular; Depth: 1.25mm; Features: Moisture Resistant; Height: 0.024inch; Lead Free: Lead Free; Length: 0.079inch; Library Name: 28Pins_Project_V1I1.SchLib; Manufacturer Part Number: RC0805FR-0722RL; Max Operating Temperature: 155°C; Military Standard: Not; Min Operating Temperature: -55°C; Number of Terminations: 2; Package Quantity: 1; Packaging: Tape and Reel; Power Rating: 125mW; Pricing 1: 1=0.10000, 10=0.03300, 100=0.01330, 1000=0.00600, 2500=0.00520 (USD); Resistance: 22R; Resistance Tolerance: 1%; Resistor Type: Thick Film; RoHS: Yes; RoHS Compliant: Yes; Series: RC; Stock 1: 394862; Supplier 1: Digi-Key; Supplier Part Number 1: 311-22.0CRCT-ND; Technology: THICK FILM; Termination: SMD/SMT; Tolerance: 1%; Width: 0.049inch; Working Voltage: 150V
R8 R26-R28 = RC0805JR-070RL: Surface Mount Thick Film Resistor, RC Series, 125 mW, - 5%, 150 V, 0805 [2012 Metric]; Manufacturer: Yageo; Max Power Dissipation: 125mW; Resistance: 0mR; Temperature Coefficient: 200ppm/°C; Voltage Rating: 150V; Case Code (Imperial): 0805; Case Code (Metric): 2012; Case/Package: 0805; Category: Chip SMD Resistors; Component Kind: Standard; ComponentLink1Description: https://datasheet.ciiva.com/7941/1738694-7941861.pdf?src-supplier=Element14; ComponentLink1URL: https://datasheet.ciiva.com/7941/1738694-7941861.pdf?src-supplier=Element14; ComponentLink2Description: https://datasheet.ciiva.com/11819/0900766b8106beab-11819430.pdf?src-supplier=Rs; ComponentLink2URL: https://datasheet.ciiva.com/11819/0900766b8106beab-11819430.pdf?src-supplier=Rs; ComponentLink3Description: https://datasheet.ciiva.com/7941/1738694-7941862.pdf?src-supplier=Element14; ComponentLink3URL: https://datasheet.ciiva.com/7941/1738694-7941862.pdf?src-supplier=Element14; ComponentLink4Description: https://datasheet.ciiva.com/7941/1738694-7941863.pdf?src-supplier=Element14; ComponentLink4URL: https://datasheet.ciiva.com/7941/1738694-7941863.pdf?src-supplier=Element14; ComponentLink5Description: https://datasheet.ciiva.com/15190/getdatasheetpartid-330685-15190028.pdf?src-supplier=Verical; ComponentLink5URL: https://datasheet.ciiva.com/15190/getdatasheetpartid-330685-15190028.pdf?src-supplier=Verical; Construction: Rectangular; Contact Plating: Tin,Matte; Depth: 1.25mm; Features: Moisture Resistant; Height: 0.5mm; Lead Free: Lead Free; Length: 2mm; Library Name: 28Pins_Project_V1I1.SchLib; Manufacturer Part Number: RC0805JR-070RL; Max Operating Temperature: 155°C; Military Standard: Not; Min Operating Temperature: -55°C; Number of Pins: 2; Number of Terminations: 2; Packaging: Tape and Reel; Power Rating: 125mW; Pricing 1: 1=0.10000, 10=0.02800, 25=0.02040, 50=0.01560, 100=0.01150, 250=0.00876, 500=0.00702, 1000=0.00517 (USD); Radiation Hardening: No; Resistance Tolerance: 5%; Resistor Type: Thick Film; RoHS: Yes; RoHS Compliant: Yes; Series: RC; Size Code: 0805; Stock 1: 4487807; Supplier 1: Digi-Key; Supplier Part Number 1: 311-0.0ARCT-ND; Technology: THICK FILM; Termination: SMD/SMT; Tolerance: 5%; Voltage Rating (DC): 150V; Width: 1.25mm
R9 R16 = AC0805FR-071ML: Res Thick Film 0805 1M Ohm 1% 0.125W(1/8W) ±100ppm/C Pad SMD Automotive T/R; Manufacturer: Yageo; Max Power Dissipation: 125mW; Resistance: 1MR; Temperature Coefficient: 100ppm/°C; Voltage Rating: 150V; Case Code (Imperial): 0805; Case Code (Metric): 2012; Case/Package: 0805; Category: Chip SMD Resistors; Component Kind: Standard; ComponentLink1Description: http://www.mouser.com/ds/2/447/yageodocoutput-1111992.pdf?src-supplier=Mouser; ComponentLink1URL: http://www.mouser.com/ds/2/447/yageodocoutput-1111992.pdf?src-supplier=Mouser; ComponentLink2Description: http://www.yageo.com/NewPortal/yageodocoutput?fileName=/pdf/R-Chip/PYu-AC_51_RoHS_L_6.pdf&src-supplier=Digi-Key; ComponentLink2URL: http://www.yageo.com/NewPortal/yageodocoutput?fileName=/pdf/R-Chip/PYu-AC_51_RoHS_L_6.pdf&src-supplier=Digi-Key; Features: Moisture Resistant,Automotive AEC-Q200; Height: 0.024inch; Lead Free: Lead Free; Length: 0.079inch; Library Name: 28Pins_Project_V1I1.SchLib; Manufacturer Part Number: AC0805FR-071ML; Max Operating Temperature: 155°C; Min Operating Temperature: -55°C; Number of Terminations: 2; Packaging: Tape and Reel; Power Rating: 125mW; Pricing 1: 1=0.10000, 10=0.04400, 100=0.01790, 1000=0.00806, 2500=0.00699 (USD); Resistor Type: Thick Film; RoHS: Yes; RoHS Compliant: Yes; Series: AC; Stock 1: 90212; Supplier 1: Digi-Key; Supplier Part Number 1: YAG3704CT-ND; Termination: SMD/SMT; Tolerance: 1%; Width: 0.049inch
R10-R12 = RC0805FR-071KL: RES SMD 1K OHM 1% 1/8W 0805; Manufacturer: Yageo; Max Power Dissipation: 125mW; Resistance: 1kR; Temperature Coefficient: 100ppm/°C; Voltage Rating: 150V; Case Code (Imperial): 0805; Case Code (Metric): 2012; Case/Package: 0805; Category: Chip SMD Resistors; Component Kind: Standard; ComponentLink1Description: https://datasheet.ciiva.com/7941/1686128-7941719.pdf?src-supplier=Element14; ComponentLink1URL: https://datasheet.ciiva.com/7941/1686128-7941719.pdf?src-supplier=Element14; ComponentLink2Description: https://datasheet.ciiva.com/46385/444164-46385020.pdf?src-supplier=Element14; ComponentLink2URL: https://datasheet.ciiva.com/46385/444164-46385020.pdf?src-supplier=Element14; ComponentLink3Description: https://datasheet.ciiva.com/2456/pyu-r-int-thick-7-2456976.pdf?src-supplier=Digi-Key; ComponentLink3URL: https://datasheet.ciiva.com/2456/pyu-r-int-thick-7-2456976.pdf?src-supplier=Digi-Key; ComponentLink4Description: https://datasheet.ciiva.com/2456/pyu-r-int-thick-7-2456977.pdf?src-supplier=Digi-Key; ComponentLink4URL: https://datasheet.ciiva.com/2456/pyu-r-int-thick-7-2456977.pdf?src-supplier=Digi-Key; ComponentLink5Description: https://datasheet.ciiva.com/2456/pyu-r-int-thick-7-2456975.pdf?src-supplier=Digi-Key; ComponentLink5URL: https://datasheet.ciiva.com/2456/pyu-r-int-thick-7-2456975.pdf?src-supplier=Digi-Key; ComponentLink6Description: https://datasheet.ciiva.com/16850/rc0805-16850409.pdf?src-supplier=Future+Electronics; ComponentLink6URL: https://datasheet.ciiva.com/16850/rc0805-16850409.pdf?src-supplier=Future+Electronics; Construction: Rectangular; Depth: 1.25mm; Features: Moisture Resistant; Height: 0.024inch; Lead Free: Lead Free; Length: 0.079inch; Library Name: 28Pins_Project_V1I1.SchLib; Manufacturer Part Number: RC0805FR-071KL; Max Operating Temperature: 155°C; Military Standard: Not; Min Operating Temperature: -55°C; Number of Pins: 2; Number of Terminations: 2; Package Quantity: 1; Packaging: Tape and Reel; Power Rating: 125mW; Pricing 1: 1=0.10000, 10=0.03300, 100=0.01330, 1000=0.00600, 2500=0.00520 (USD); Reach SVHC Compliant: Yes; Resistance Tolerance: 1%; Resistor Type: Thick Film; RoHS: Yes; RoHS Compliant: Yes; Series: RC; Size Code: 0805; Stock 1: 8339526; Supplier 1: Digi-Key; Supplier Part Number 1: 311-1.00KCRCT-ND; Termination: SMD/SMT; Tolerance: 1%; Voltage Rating (DC): 150V; Width: 0.049inch
SCKT_FOR_U1 = 4828-3004-CP: Socket DIP 28Cnts DualWipe 0.3InSpc. PhosphorBronze Nickel KinkedTail 4800Series; Manufacturer: 3M; Current Rating: 1A; Gender: Female; Insulation Resistance: 5GR; Number of Contacts: 28; Pitch: 2.54mm; Row Spacing: 7.62mm; Category: Connectors; Component Kind: Standard; ComponentLink1Description: https://datasheet.ciiva.com/768/ts1099-768354.pdf?src-supplier=Digi-Key; ComponentLink1URL: https://datasheet.ciiva.com/768/ts1099-768354.pdf?src-supplier=Digi-Key; Contact Finish - Mating: TIN; Contact Material: Bronze; Contact Plating: Tin; Depth: 10.16mm; Flammability Rating: UL94 V-0; Housing Material: Glass; Insulation Material: Polyester; Lead Free: Lead Free; Length: 35.43mm; Library Name: 28Pins_Project_V1I1.SchLib; Manufacturer Part Number: 4828-3004-CP; Max Operating Temperature: 85°C; Min Operating Temperature: -25°C; Mount: Through Hole; Number of Positions: 28; Number of Rows: 2; Orientation: Straight; Package Quantity: 5600; Packaging: Rail/Tube; Pricing 1: 1=1.06000, 10=0.89600, 25=0.85880, 50=0.84060, 100=0.80410, 250=0.73104, 500=0.65794, 1000=0.58483, 2500=0.53000 (USD); RoHS: Yes; RoHS Compliant: Yes; Series: 4800; Stock 1: 14821; Supplier 1: Digi-Key; Supplier Part Number 1: 3M5480-ND; Termination: Solder; Width: 10.16mm
SW1 SW2 = 1825910-6: Switch Tactile OFF (ON) SPST Round Button PC Pins 0.05A 24VDC 1.57N Thru-Hole Loose; Manufacturer: TE Connectivity Alcoswitch; Actuator Color: Black; Actuator Length: 0.055inch; Actuator Material: PBT; Actuator Type: Standard; Contact Current Rating: 50mA; Contact Resistance: 100mR; Electrical Life: 100000Cycles; Illumination Type: Non-Illuminated,Illuminated; Max Voltage Rating (DC): 24V; Operating Force: 160gf; Switch Function: Off-Mom; Switch Travel: 0.25mm; Category: Pushbutton Switches; Component Kind: Standard; ComponentLink1Description: https://datasheet.ciiva.com/30199/1825910-6rqpn1825910-6-30199520.pdf?src-supplier=Onlinecomponents.com; ComponentLink1URL: https://datasheet.ciiva.com/30199/1825910-6rqpn1825910-6-30199520.pdf?src-supplier=Onlinecomponents.com; ComponentLink2Description: https://datasheet.ciiva.com/15184/getdatasheetpartid-395915-15184509.pdf?src-supplier=Verical; ComponentLink2URL: https://datasheet.ciiva.com/15184/getdatasheetpartid-395915-15184509.pdf?src-supplier=Verical; Contact Material: Copper Alloy; Contact Plating: Silver; ELV: Compliant; Lead Free: Lead Free; Length: 5.99mm; Library Name: 28Pins_Project_V1I1.SchLib; Manufacturer Part Number: 1825910-6; Max Current Rating: 50mA; Max Operating Temperature: 85°C; Mechanical Life: 100000Cycles; Min Operating Temperature: -35°C; Mount: Through Hole; Orientation: Straight; Package Quantity: 750; Packaging: Loose Piece; Pricing 1: 1=0.13000, 10=0.12500, 25=0.11840, 100=0.10780, 250=0.10132, 500=0.09268, 1000=0.07759, 2500=0.07544, 5000=0.07113 (USD); RoHS: Yes; RoHS Compliant: Yes; Series: FSMJ; Stock 1: 76318; Supplier 1: Digi-Key; Supplier Part Number 1: 450-1650-ND; Termination: PC Pins; Throw Configuration: SPST; Type: Switch
U1 = ATMEGA328P-PN: MCU, 8BIT, AVR, 20MHZ, DIP-28; Controller Family/Series: AVR MEGA; Core Size: 8bit; Manufacturer: Microchip; Data Bus Width: 8b; Interface: SPI,USART,2-Wire,I2C,UART; Max Supply Voltage: 5.5V; Memory Size: 32KB; Memory Type: FLASH; Min Supply Voltage: 1.8V; Number of ADC Channels: 8; Number of I/Os: 23; Number of PWM Channels: 6; Number of Timers/Counters: 3; Oscillator Type: Internal; Peripherals: Brown-out Detect/Reset,POR,PWM,WDT; RAM Size: 2KB; Watchdog Timer: Yes; Case/Package: PDIP; Category: Uncategorized; Component Kind: Standard; ComponentLink1Description: http://www.mouser.com/ds/2/36/atmel-8271-8-bit-avr-microcontroller-atmega48a-48p-365589.pdf?src-supplier=Mouser; ComponentLink1URL: http://www.mouser.com/ds/2/36/atmel-8271-8-bit-avr-microcontroller-atmega48a-48p-365589.pdf?src-supplier=Mouser; ComponentLink2Description: http://uk.rs-online.com/webdocs/1441/0900766b81441b3d.pdf?src-supplier=Rs; ComponentLink2URL: http://uk.rs-online.com/webdocs/1441/0900766b81441b3d.pdf?src-supplier=Rs; ComponentLink3Description: http://www.atmel.com/Images/Atmel-8271-8-bit-AVR-Microcontroller-ATmega48A-48PA-88A-88PA-168A-168PA-328-328P_datasheet.pdf?src-supplier=Avnet; ComponentLink3URL: http://www.atmel.com/Images/Atmel-8271-8-bit-AVR-Microcontroller-ATmega48A-48PA-88A-88PA-168A-168PA-328-328P_datasheet.pdf?src-supplier=Avnet; ComponentLink4Description: http://www.microchip.com/mymicrochip/filehandler.aspx?ddocname=en589968&src-supplier=Digi-Key; ComponentLink4URL: http://www.microchip.com/mymicrochip/filehandler.aspx?ddocname=en589968&src-supplier=Digi-Key; ComponentLink5Description: http://www.farnell.com/datasheets/2047852.pdf?src-supplier=Farnell; ComponentLink5URL: http://www.farnell.com/datasheets/2047852.pdf?src-supplier=Farnell; ComponentLink6Description: http://www.farnell.com/datasheets/2047852.pdf?src-supplier=Element14; ComponentLink6URL: http://www.farnell.com/datasheets/2047852.pdf?src-supplier=Element14; ComponentLink7Description: http://www.farnell.com/datasheets/1693866.pdf?src-supplier=Newark; ComponentLink7URL: http://www.farnell.com/datasheets/1693866.pdf?src-supplier=Newark; ComponentLink8Description: http://www.microchip.com/mymicrochip/filehandler.aspx?ddocname=en608326&src-supplier=Digi-Key; ComponentLink8URL: http://www.microchip.com/mymicrochip/filehandler.aspx?ddocname=en608326&src-supplier=Digi-Key; Contact Plating: Tin,Matte; Core Architecture: AVR; Frequency: 20MHz; Height: 4.064mm; Lead Free: Lead Free; Length: 34.8mm; Library Name: 28Pins_Project_V1I1.SchLib; Manufacturer Part Number: ATMEGA328P-PN; Max Frequency: 20MHz; Max Operating Temperature: 105°C; Min Operating Temperature: -40°C; Mount: Through Hole; Number of Pins: 28; Number of Programmable I/O: 23; Package Quantity: 14; Packaging: Rail/Tube; Pricing 1: 1=3.25000, 25=2.97840, 100=2.70000 (USD); Reach SVHC Compliant: Yes; RoHS: Yes; RoHS Compliant: Yes; Series: AVR® ATmega; Stock 1: 1147; Supplier 1: Digi-Key; Supplier Part Number 1: ATMEGA328P-PN-ND; Width: 7.493mm
U2 = ATMEGA16U2-AU: 8-bit AVR MCU, 16 KB, 512 Bytes, 16 MHz, 2.7 to 5.5V, TQFP-32, RoHS; Manufacturer: Microchip; Data Bus Width: 8b; Frequency: 16MHz; Interface: SPI,USB,UART,USART,Serial; Memory Size: 16KB; Memory Type: FLASH; Min Supply Voltage: 2.7V; Number of I/Os: 22; Number of PWM Channels: 5; Number of SPI Channels: 1; Number of Timers/Counters: 2; Oscillator Type: Internal; Peripherals: Brown-out Detect/Reset,POR,PWM,WDT; RAM Size: 512B; Watchdog Timer: Yes; Case/Package: A; Category: Uncategorized; Component Kind: Standard; ComponentLink1Description: https://datasheet.ciiva.com/13036/doc7799-28769-13036800.pdf?src-supplier=Mouser; ComponentLink1URL: https://datasheet.ciiva.com/13036/doc7799-28769-13036800.pdf?src-supplier=Mouser; ComponentLink2Description: https://datasheet.ciiva.com/22651/doc7799-22651110.pdf?src-supplier=Farnell; ComponentLink2URL: https://datasheet.ciiva.com/22651/doc7799-22651110.pdf?src-supplier=Farnell; Contact Plating: Tin,Matte; Core Architecture: AVR; Height: 1.05mm; Lead Free: Lead Free; Length: 7mm; Library Name: 28Pins_Project_V1I1.SchLib; Manufacturer Part Number: ATMEGA16U2-AU; Max Frequency: 16MHz; Max Operating Temperature: 85°C; Max Supply Voltage: 5.5V; Min Operating Temperature: -40°C; Mount: Surface Mount; Number of Pins: 32; Number of Programmable I/O: 22; Package Quantity: 1250; Packaging: Tray; Pricing 1: 1=3.48000, 25=3.19720, 100=2.89800 (USD); Reach SVHC Compliant: Yes; RoHS: Yes; RoHS Compliant: Yes; Series: AVR® ATmega; Stock 1: 34966; Supplier 1: Digi-Key; Supplier Part Number 1: ATMEGA16U2-AU-ND; Width: 7mm
U3 = TL1963A-33DCQT: LDO Regulator Pos 3.3V 1.5A 6-Pin(5+Tab) SOT-223 T/R; Manufacturer: Texas Instruments; Accuracy: 3%; Dropout Voltage: 340mV; Max Input Voltage: 20V; Min Current Limit: 1.6A; Min Input Voltage: 2.1V; Number of Outputs: 1; Output Type: Fixed; Polarity: Positive; Power Supply Rejection Ratio (PSRR): 63dB; Quiescent Current: 1mA; Case/Package: SOT-223; Category: Voltage Regulators - Linear; Component Kind: Standard; ComponentLink1Description: https://datasheet.ciiva.com/14279/tl1963a-33-14279286.pdf?src-supplier=Avnet; ComponentLink1URL: https://datasheet.ciiva.com/14279/tl1963a-33-14279286.pdf?src-supplier=Avnet; ComponentLink2Description: https://datasheet.ciiva.com/6591/tl1963a-6591406.pdf?src-supplier=Digi-Key; ComponentLink2URL: https://datasheet.ciiva.com/6591/tl1963a-6591406.pdf?src-supplier=Digi-Key; ComponentLink3Description: https://datasheet.ciiva.com/6591/tl1963a-6591431.pdf?src-supplier=Digi-Key; ComponentLink3URL: https://datasheet.ciiva.com/6591/tl1963a-6591431.pdf?src-supplier=Digi-Key; ComponentLink4Description: https://datasheet.ciiva.com/6591/tl1963a-6591407.pdf?src-supplier=Digi-Key; ComponentLink4URL: https://datasheet.ciiva.com/6591/tl1963a-6591407.pdf?src-supplier=Digi-Key; Contact Plating: Gold; Lead Free: Lead Free; Library Name: 28Pins_Project_V1I1.SchLib; Manufacturer Part Number: TL1963A-33DCQT; Max Operating Temperature: 125°C; Max Output Current: 1.5A; Max Output Voltage: 3.3V; Min Operating Temperature: -40°C; Min Output Voltage: 3.3V; Number of Pins: 6; Number of Regulators: 1; Output Current: 1.5A; Output Voltage: 3.3V; Package Quantity: 250; Packaging: Tape and Reel; Pricing 1: 1=4.28000, 10=3.84500, 25=3.63480, 100=3.15010 (USD); Radiation Hardening: No; RoHS: Yes; RoHS Compliant: Yes; Series: -; Stock 1: 2578; Supplier 1: Digi-Key; Supplier Part Number 1: 296-32342-1-ND
U4-U7 = SN74AHC1G09DBVR: Single 2-input, 2-V to 5.5-V AND gate with open-drain outputs 5-SOT-23 -55 to 125; Manufacturer: Texas Instruments; Low Level Output Current: 8mA; Max Supply Voltage: 5.5V; Min Supply Voltage: 2V; Number of Bits: 1; Number of Inputs: 2; Output Current: 25mA; Propagation Delay: 7.5ns; Quiescent Current: 1uA; Case/Package: SOT-23; Category: Logic Gates; Component Kind: Standard; ComponentLink1Description: https://datasheet.ciiva.com/1864/sn74ahc1g09-1864411.pdf?src-supplier=Digi-Key; ComponentLink1URL: https://datasheet.ciiva.com/1864/sn74ahc1g09-1864411.pdf?src-supplier=Digi-Key; ComponentLink2Description: https://datasheet.ciiva.com/1864/sn74ahc1g09-1864410.pdf?src-supplier=Digi-Key; ComponentLink2URL: https://datasheet.ciiva.com/1864/sn74ahc1g09-1864410.pdf?src-supplier=Digi-Key; ComponentLink3Description: https://datasheet.ciiva.com/1864/sn74ahc1g09-1864412.pdf?src-supplier=Digi-Key; ComponentLink3URL: https://datasheet.ciiva.com/1864/sn74ahc1g09-1864412.pdf?src-supplier=Digi-Key; Contact Plating: Tin,Matte; Lead Free: Lead Free; Library Name: 28Pins_Project_V1I1.SchLib; Logic Function: AND GATE; Manufacturer Part Number: SN74AHC1G09DBVR; Max Operating Temperature: 125°C; Min Operating Temperature: -55°C; Mount: Surface Mount; Number of Circuits: 1; Number of Elements: 1; Number of Gates: 1; Number of Outputs: 1; Number of Pins: 5; Output Voltage: 5.5V; Package Quantity: 3000; Packaging: Tape and Reel; Pricing 1: 1=0.41000, 10=0.30500, 25=0.26720, 100=0.17290, 250=0.14312, 500=0.11448, 1000=0.08777 (USD); Radiation Hardening: No; Reach SVHC Compliant: Yes; RoHS: Yes; RoHS Compliant: Yes; Series: 74AHC; Stock 1: 1878; Supplier 1: Digi-Key; Supplier Part Number 1: 296-29202-1-ND; Technology: CMOS
Y1 = ABM7-10.000MHZ-D2Y-T: Crystal 10MHz ±20ppm (Tol) ±30ppm (Stability) 18pF FUND 80Ohm 2-Pin Mini-CSMD T/R; Manufacturer: Abracon; ESR (Equivalent Series Resistance): 60R; Case/Package: SMD/SMT; Category: Crystals; Component Kind: Standard; ComponentLink1Description: https://datasheet.ciiva.com/16397/abm7-16397372.pdf?src-supplier=Future+Electronics; ComponentLink1URL: https://datasheet.ciiva.com/16397/abm7-16397372.pdf?src-supplier=Future+Electronics; ComponentLink2Description: https://datasheet.ciiva.com/5788/abm7-5788054.pdf?src-supplier=Farnell; ComponentLink2URL: https://datasheet.ciiva.com/5788/abm7-5788054.pdf?src-supplier=Farnell; ComponentLink3Description: https://datasheet.ciiva.com/7998/947971-7998600.pdf?src-supplier=Element14; ComponentLink3URL: https://datasheet.ciiva.com/7998/947971-7998600.pdf?src-supplier=Element14; ComponentLink4Description: https://datasheet.ciiva.com/22658/947971-22658293.pdf?src-supplier=Element14; ComponentLink4URL: https://datasheet.ciiva.com/22658/947971-22658293.pdf?src-supplier=Element14; ComponentLink5Description: https://datasheet.ciiva.com/46385/947971-46385243.pdf?src-supplier=Element14; ComponentLink5URL: https://datasheet.ciiva.com/46385/947971-46385243.pdf?src-supplier=Element14; ComponentLink6Description: https://datasheet.ciiva.com/18649/abm7-18649866.pdf?src-supplier=Digi-Key; ComponentLink6URL: https://datasheet.ciiva.com/18649/abm7-18649866.pdf?src-supplier=Digi-Key; ComponentLink7Description: https://datasheet.ciiva.com/18649/abm7-18649865.pdf?src-supplier=Digi-Key; ComponentLink7URL: https://datasheet.ciiva.com/18649/abm7-18649865.pdf?src-supplier=Digi-Key; ComponentLink8Description: https://datasheet.ciiva.com/18649/abm7-18649867.pdf?src-supplier=Digi-Key; ComponentLink8URL: https://datasheet.ciiva.com/18649/abm7-18649867.pdf?src-supplier=Digi-Key; ComponentLink9Description: https://datasheet.ciiva.com/16383/abm7-16383174.pdf?src-supplier=Future+Electronics; ComponentLink9URL: https://datasheet.ciiva.com/16383/abm7-16383174.pdf?src-supplier=Future+Electronics; ComponentLink10Description: https://datasheet.ciiva.com/26941/getdatasheetpartid-719818-26941724.pdf?src-supplier=Verical; ComponentLink10URL: https://datasheet.ciiva.com/26941/getdatasheetpartid-719818-26941724.pdf?src-supplier=Verical; Depth: 3.5mm; Frequency: 10MHz; Frequency Stability: 30ppm; Frequency Tolerance: 20ppm; Height: 1.4mm; Lead Free: Lead Free; Length: 6mm; Library Name: 28Pins_Project_V1I1.SchLib; Load Capacitance: 18pF; Manufacturer Part Number: ABM7-10.000MHZ-D2Y-T; Max Operating Temperature: 85°C; Min Operating Temperature: -40°C; Mount: Surface Mount; Number of Pins: 2; Operating Frequency: 10 MHz; Package Quantity: 1000; Packaging: Tape and Reel; Pricing 1: 1=0.67000, 10=0.55600, 50=0.50000, 100=0.44440, 500=0.42218 (USD); Radiation Hardening: No; Resistor Type: Ceramic; RoHS: Yes; Series: ABM7; Stock 1: 22989; Supplier 1: Digi-Key; Supplier Part Number 1: 535-9833-1-ND; Termination: SMD/SMT; Tolerance: 20ppm; Width: 3.3mm; Series Resistance: 60R
